# Altium schematic sheet CN032-1 Industry EtherCAT Servo Controller_QSPI.SchDoc
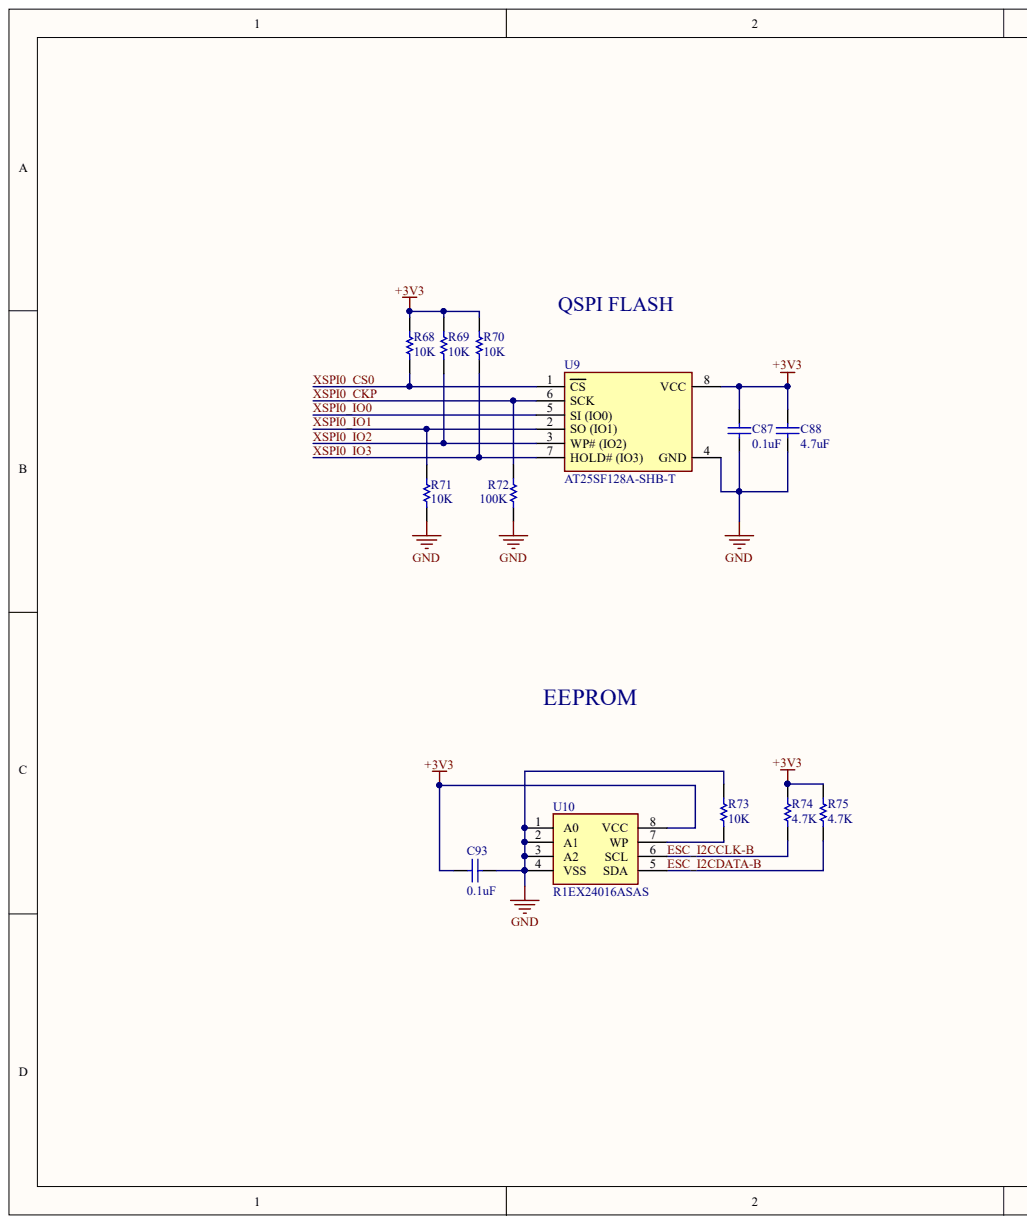
[diagram p0: CN032-1 Industry EtherCAT Servo Controller_QSPI.SchDoc, left]
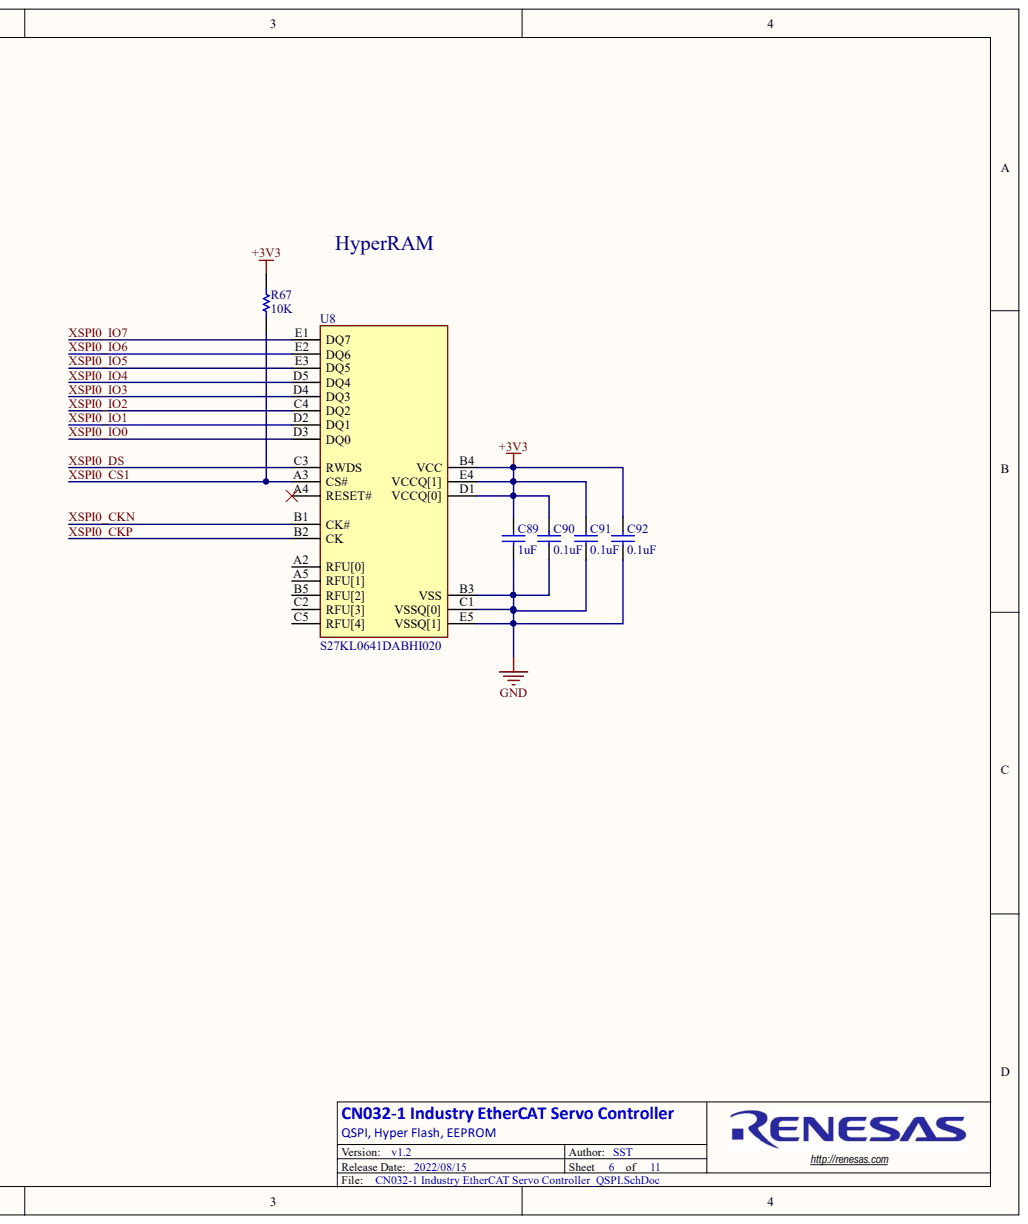
[diagram p1: CN032-1 Industry EtherCAT Servo Controller_QSPI.SchDoc, right]

## Parts
C87  0.1uF  [CAPC0402-01]
C88  4.7uF  [CAPC0402-01]
C89  1uF  [CAPC0402-01]
C90  0.1uF  [CAPC0402-01]
C91  0.1uF  [CAPC0402-01]
C92  0.1uF  [CAPC0402-01]
C93  0.1uF  [CAPC0402-01]
R67  10K  [RESC0402-01]
R68  10K  [RESC0402-01]
R69  10K  [RESC0402-01]
R70  10K  [RESC0402-01]
R71  10K  [RESC0402-01]
R72  100K  [RESC0402-01]
R73  10K  [RESC0402-01]
R74  4.7K  [RESC0402-01]
R75  4.7K  [RESC0402-01]
U8  S27KL0641DABHI020  [S27KL0641]
U9  AT25SF128A-SHB-T  [SOIC-8 (208-mil)]
U10  R1EX24016ASAS  [SOIC-8 (150-mil)]

## Nets
+3V3: C87.2 C88.2 C89.2 C90.2 C91.2 C92.2 C93.1 R67.2 R68.2 R69.2 R70.2 R74.2 R75.2 U8.B4(VCC) U8.D1(VCCQ[0]) U8.E4(VCCQ[1]) U9.8(VCC) U10.8(VCC)
GND: C87.1 C88.1 C89.1 C90.1 C91.1 C92.1 C93.2 R71.1 R72.1 R73.2 U8.B3(VSS) U8.C1(VSSQ[0]) U8.E5(VSSQ[1]) U9.4(GND) U10.1(A0) U10.2(A1) U10.3(A2) U10.4(VSS)
ESC_I2CCLK-B: R74.1 U10.6(SCL)
ESC_I2CDATA-B: R75.1 U10.5(SDA)
XSPI0_CKN: U8.B1(CK#)
XSPI0_CKP: R72.2 U8.B2(CK) U9.6(SCK)
XSPI0_CS0: R68.1 U9.1(CS)
XSPI0_CS1: R67.1 U8.A3(CS#)
XSPI0_DS: U8.C3(RWDS)
XSPI0_IO0: U8.D3(DQ0) U9.5(SI_(IO0))
XSPI0_IO1: R71.2 U8.D2(DQ1) U9.2(SO_(IO1))
XSPI0_IO2: R69.1 U8.C4(DQ2) U9.3(WP#_(IO2))
XSPI0_IO3: R70.1 U8.D4(DQ3) U9.7
XSPI0_IO4: U8.D5(DQ4)
XSPI0_IO5: U8.E3(DQ5)
XSPI0_IO6: U8.E2(DQ6)
XSPI0_IO7: U8.E1(DQ7)
NetR73_1: R73.1 U10.7(WP)

Not connected: U8 A2(RFU[0]) A4(RESET#) A5(RFU[1]) B5(RFU[2]) C2(RFU[3]) C5(RFU[4])

## Library data of the parts
C87 C90-C93 = CAP-0402-0.1uF-YAGEO: Ceramic Chip Capacitor 0402 0.1uF 16V; Manufacturer: YAGEO; Mfg Part Number: CC0402KPX7R7BB104; Dialectric: X7R; Tolerance: 10%; Capacitance: 0.1uF; Component Kind: Standard; Package: 0402; Voltage: 16V; Library Name: Synergy.SchLib
C88 = CAP-0402-4.7uF-YAGEO: Ceramic Chip Capacitor 0402 4.7uF 6.3V; Manufacturer: YAGEO; Mfg Part Number: CC0402MRX5R5BB475; Dialectric: X5R; Tolerance: 20%; Capacitance: 4.7uF; Component Kind: Standard; Package: 0402; Voltage: 6.3V; Library Name: Synergy.SchLib
C89 = CAP-0402-1uF: Ceramic Chip Capacitor 0402 1uF 16V; Manufacturer: YAGEO; Mfg Part Number: CC0402KRX5R7BB105; Dialectric: X5R; Tolerance: 10%; Capacitance: 1uF; Component Kind: Standard; Package: 0402; Voltage: 16V; Library Name: Synergy.SchLib
R67-R71 R73 = RES-0402-10K: Chip Resistor Thick Film 0402 10K 1% 1/16W; Manufacturer: YAGEO; Mfg Part Number: RC0402FR-0710KL; Component Kind: Standard; Package: 0402; Power: 1/16W; Resistance: 10K; Tolerance: 1%; Library Name: Synergy.SchLib
R72 = RES-0402-100K: Chip Resistor Thick Film 0402 100K 1% 1/16W; Manufacturer: YAGEO; Mfg Part Number: RC0402FR-07100KL; Component Kind: Standard; Package: 0402; Power: 1/16W; Resistance: 100K; Tolerance: 1%; Library Name: Synergy.SchLib
R74 R75 = RES-0402-4.7K: Chip Resistor Thick Film 0402 4.7K 1% 1/16W; Manufacturer: YAGEO; Mfg Part Number: RC0402FR-074K7L; Component Kind: Standard; Package: 0402; Power: 1/16W; Rating: 1%; Value: 4.7K; Library Name: Synergy.SchLib
U8 = ICN-HyperRAM-S27KL0641: IC PSRAM 64MBIT PARALLEL 24FBGA; Manufacturer: Cypress Semiconductor; Component Kind: Standard; Display Name: S27KL0641DABHI020; Mfg Part Number: S27KL0641DABHI020; Package: 24-FBGA（6x8）; Library Name: Synergy.SchLib
U9 = ICN-AT25SF041B-SHD-B: IC FLASH 128MBIT SPI/QUAD 8SOIC; Manufacturer: Renesas; Component Kind: Standard; Display Name: AT25SF128A-SHB-T; Mfg Part Number: AT25SF128A-SHB-T; Package: 8SOIC; Library Name: Synergy.SchLib
U10 = ICN-EEPROM-R1EX24002ASAS0A#S0: IC EEPROM 16KBIT I2C 400KHZ 8SOP; Manufacturer: Renesas; Component Kind: Standard; Display Name: R1EX24016ASAS; Mfg Part Number: R1EX24016ASAS; Package: 8SOP; Library Name: Synergy.SchLib
